# Altium schematic sheet I2C_Interface.SchDoc(I2C_Interface)
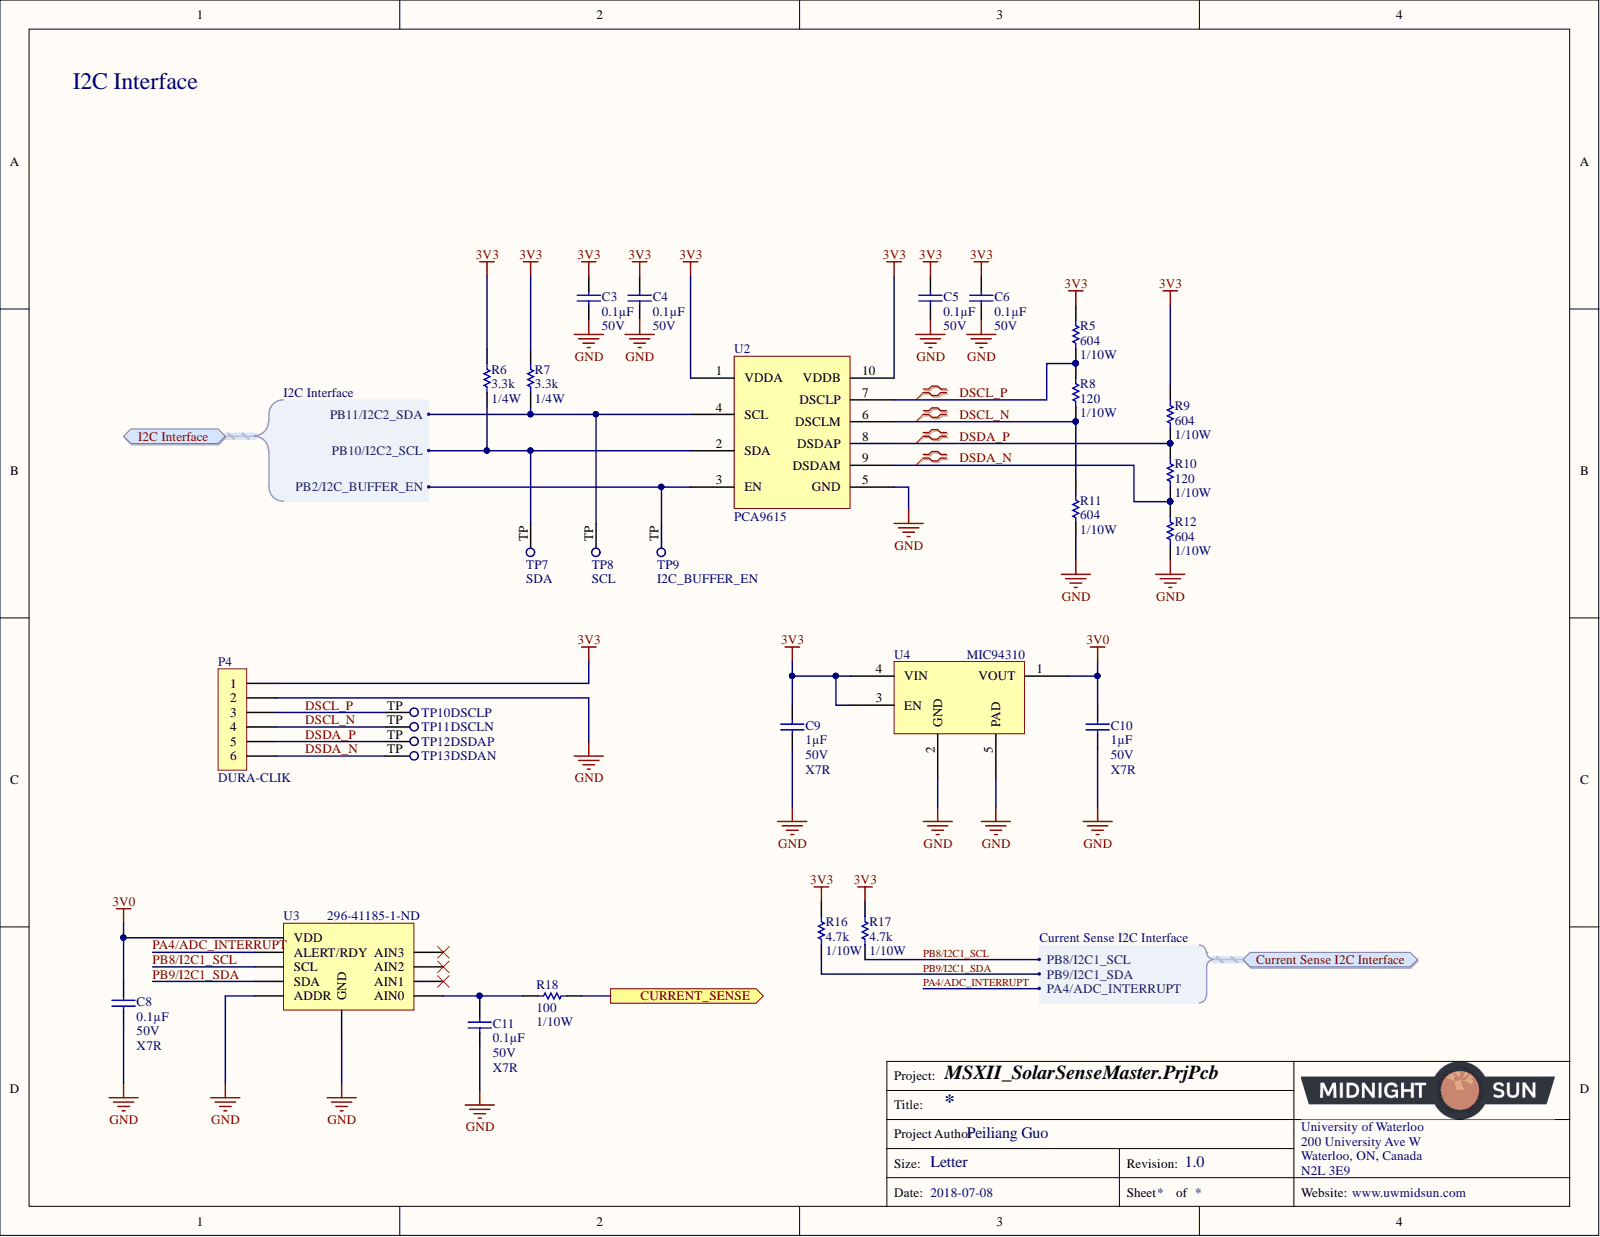
[diagram p0: I2C_Interface.SchDoc]

## Parts
C3  Voltage: 50V  Capacitance: 0.1µF  [CAP, 0603]  (hidden comment: CAP CER 0.1UF 50V 10% X7R 0603)
C4  Voltage: 50V  Capacitance: 0.1µF  [CAP, 0603]  (hidden comment: CAP CER 0.1UF 50V 10% X7R 0603)
C5  Voltage: 50V  Capacitance: 0.1µF  [CAP, 0603]  (hidden comment: CAP CER 0.1UF 50V 10% X7R 0603)
C6  Voltage: 50V  Capacitance: 0.1µF  [CAP, 0603]  (hidden comment: CAP CER 0.1UF 50V 10% X7R 0603)
C8  Voltage: 50V  Temperature Coefficient: X7R  Capacitance: 0.1µF  [CAP, 0603]  (hidden comment: CAP CER 0.1UF 50V 10% X7R 0603)
C9  Voltage: 50V  Temperature Coefficient: X7R  Capacitance: 1µF  [CAP, 0603]  (hidden comment: CAP CER 1UF 50V 10% X7R 0603)
C10  Voltage: 50V  Temperature Coefficient: X7R  Capacitance: 1µF  [CAP, 0603]  (hidden comment: CAP CER 1UF 50V 10% X7R 0603)
C11  Voltage: 50V  Temperature Coefficient: X7R  Capacitance: 0.1µF  [CAP, 0603]  (hidden comment: CAP CER 0.1UF 50V 10% X7R 0603)
P4  DURA-CLIK  [CONN, 6POS DURA-CLIK VERT]
R5  Power: 1/10W  [RES, 0603]  (hidden comment: RES 604 OHM 1% 1/10W 0603)
R6  Resistance: 3.3k  Power: 1/4W  [RES, 0603]  (hidden comment: RES 3.3K OHM 1% 1/4W 0603)
R7  Resistance: 3.3k  Power: 1/4W  [RES, 0603]  (hidden comment: RES 3.3K OHM 1% 1/4W 0603)
R8  Power: 1/10W  [RES, 0603]  (hidden comment: RES 120 OHM 1% 1/10W 0603)
R9  Power: 1/10W  [RES, 0603]  (hidden comment: RES 604 OHM 1% 1/10W 0603)
R10  Power: 1/10W  [RES, 0603]  (hidden comment: RES 120 OHM 1% 1/10W 0603)
R11  Power: 1/10W  [RES, 0603]  (hidden comment: RES 604 OHM 1% 1/10W 0603)
R12  Power: 1/10W  [RES, 0603]  (hidden comment: RES 604 OHM 1% 1/10W 0603)
R16  Resistance (Ohms): 4.7k  Power (Watts): 1/10W  [RES, 0603]  (hidden comment: RES 4.7K OHM 1% 1/10W 0603)
R17  Resistance (Ohms): 4.7k  Power (Watts): 1/10W  [RES, 0603]  (hidden comment: RES 4.7K OHM 1% 1/10W 0603)
R18  Power: 1/10W  [RES, 0603]  (hidden comment: RES 100 OHM 1% 1/10W 0603)
TP7  SDA  [Test Point]  (no BOM)
TP8  SCL  [Test Point]  (no BOM)
TP9  I2C_BUFFER_EN  [Test Point]  (no BOM)
TP10  DSCLP  [Test Point]  (no BOM)
TP11  DSCLN  [Test Point]  (no BOM)
TP12  DSDAP  [Test Point]  (no BOM)
TP13  DSDAN  [Test Point]  (no BOM)
U2  Base Part Number: PCA9615  [TSSOP50P490X110-10N]  (hidden comment: IC DIFFERENTIAL I2C BUFFER PCA9615)
U3  Supplier Part Number 1: 296-41185-1-ND  [IC ADC VSSOP10]  (hidden comment: Quad ADC)
U4  MIC94310  [IC REG LDO 3V 0.2A 4-TDFN]

## Ports
Current Sense I2C Interface, Current Sense I2C Interface.PA4/ADC_INTERRUPT, Current Sense I2C Interface.PB8/I2C1_SCL, Current Sense I2C Interface.PB9/I2C1_SDA, CURRENT_SENSE, I2C Interface, I2C Interface.PB2/I2C_BUFFER_EN, I2C Interface.PB10/I2C2_SCL, I2C Interface.PB11/I2C2_SDA

## Nets
3V0: C8.1 C10.1 U3.8(VDD) U4.1(VOUT)
3V3: C3.1 C4.1 C5.1 C6.1 C9.1 P4.1 R5.1 R6.1 R7.1 R9.1 R16.1 R17.1 U2.1(VDDA) U2.10(VDDB) U4.3(EN) U4.4(VIN)
GND: C3.2 C4.2 C5.2 C6.2 C8.2 C9.2 C10.2 C11.2 P4.2 R11.2 R12.2 U2.5(GND) U3.1(ADDR) U3.3(GND) U4.2(GND) U4.5(PAD)
CURRENT_SENSE: R18.1 ; port CURRENT_SENSE
DSCL_N: P4.4 R8.2 R11.1 TP11.TP U2.6(DSCLM)
DSCL_P: P4.3 R5.2 R8.1 TP10.TP U2.7(DSCLP)
DSDA_N: P4.6 R10.2 R12.1 TP13.TP U2.9(DSDAM)
DSDA_P: P4.5 R9.2 R10.1 TP12.TP U2.8(DSDAP)
PA4/ADC_INTERRUPT: U3.2(ALERT/RDY) ; port Current Sense I2C Interface
PB8/I2C1_SCL: R17.2 U3.10(SCL) ; port Current Sense I2C Interface
PB9/I2C1_SDA: R16.2 U3.9(SDA) ; port Current Sense I2C Interface
NetC11_1: C11.1 R18.2 U3.4(AIN0)
NetR6_2: R6.2 TP7.TP U2.2(SDA) ; port I2C Interface
NetR7_2: R7.2 TP8.TP U2.4(SCL) ; port I2C Interface
NetTP9_TP: TP9.TP U2.3(EN) ; port I2C Interface

Not connected: U3 5(AIN1) 6(AIN2) 7(AIN3)

## Library data of the parts
C3-C6 C8 C11 = CAP CER 0.1UF 50V 10% X7R 0603: 0.10µF ±10% 50V Ceramic Capacitor X7R 0603 (1608 Metric); Manufacturer Part Number 1: 06035C104KAT2A; Manufacturer 1: AVX Corporation; Tolerance: ±10%; Thickness (Max): 0.035" (0.90mm); Supplier Part Number 1: 478-5052-1-ND; Supplier 1: Digi-Key; Size / Dimension: 0.063" L x 0.032" W (1.60mm x 0.81mm); Ratings: -; Part Status: Active; Packaging: Cut Tape (CT); Package / Case: 0603 (1608 Metric); Operating Temperature: -55°C ~ 125°C; Mounting Type: Surface Mount, MLCC; Lead Style: -; Lead Spacing: -; Height - Seated (Max): -; ComponentLink1URL: http://datasheets.avx.com/X7RDielectric.pdf; ComponentLink1Description: http://datasheets.avx.com/X7RDielectric.pdf; Component Kind: Standard; Library Name: Schematic Diagrams.SchLib
  C3-C6: Temperature Coefficient: X7R
C9 C10 = CAP CER 1UF 50V 10% X7R 0603: 1µF ±10% 50V Ceramic Capacitor X7R 0603 (1608 Metric); Manufacturer Part Number 1: UMK107AB7105KA-T; Manufacturer 1: Taiyo Yuden; Tolerance: ±10%; Thickness (Max): 0.037" (0.95mm); Supplier Part Number 1: 587-3247-1-ND; Supplier 1: Digi-Key; Size / Dimension: 0.063" L x 0.031" W (1.60mm x 0.80mm); Ratings: -; Part Status: Active; Packaging: Cut Tape (CT); Package / Case: 0603 (1608 Metric); Operating Temperature: -55°C ~ 125°C; Mounting Type: Surface Mount, MLCC; Lead Style: -; Lead Spacing: -; Height - Seated (Max): -; ComponentLink1URL: http://www.yuden.co.jp/productdata/catalog/en/mlcc_all_e.pdf; ComponentLink1Description: http://www.yuden.co.jp/productdata/catalog/en/mlcc_all_e.pdf; Component Kind: Standard; Library Name: Schematic Diagrams.SchLib
P4 = CONN 6POS DURA-CLIK 0.079": 6 Positions Header, Shrouded Connector 0.079" (2.00mm) Surface Mount, Vertical Tin; Manufacturer Part Number: 5600200820; Manufacturer: Molex; Style: Board to Cable/Wire; Shrouding: Shrouded - 4 Wall; Number of Positions Loaded: All; Number of Positions: 6; Mated Stacking Heights: 9.3mm; Voltage Rating: -; Termination: Solder; Supplier Part Number 1: WM10866CT-ND; Supplier 1: Digi-Key; Stock 1: 3826; Row Spacing - Mating: -; RoHS: RoHS Compliant; Pricing 1: 1=1.76, 10=1.542, 25=1.4512, 50=1.391, 100=1.3305, 250=1.20956 (CAD); Pitch - Mating: 0.079" (2.00mm); Part Status: Active; Packaging: Cut Tape (CT); Overall Contact Length: -; Operating Temperature: -; Number of Rows: 1; Mounting Type: Surface Mount; Material Flammability Rating: -; Insulation Material: Polyamide (PA), Nylon; Insulation Height: 0.315" (8.00mm); Insulation Color: Natural; Ingress Protection: -; Features: Solder Retention; Fastening Type: Latch Lock; Current Rating: -; Contact Type: Male Pin; Contact Shape: Square; Contact Material: Copper Alloy; Contact Length - Post: -; Contact Length - Mating: -; Contact Finish Thickness - Post: -; Contact Finish Thickness - Mating: -; Contact Finish - Post: Tin; Contact Finish - Mating: Tin; Connector Type: Header; ComponentLink1URL: http://www.molex.com/pdm_docs/sd/5600200220_sd.pdf; ComponentLink1Description: http://www.molex.com/pdm_docs/sd/5600200220_sd.pdf; Component Kind: Standard; Category: Connectors, Interconnects; Applications: Automotive, Industrial; Library Name: Schematic Diagrams.SchLib
R5 R9 R11 R12 = RES 604 OHM 1% 1/10W 0603: RES SMD 604 OHM 1% 1/10W 0603; Manufacturer Part Number 1: RC0603FR-07604RL; Manufacturer 1: Yageo; Temperature Coefficient: ±100ppm/°C; Resistance: 604; Tolerance: ±1%; Supplier Part Number 1: 311-604HRCT-ND; Supplier Device Package: 0603; Supplier 1: Digi-Key; Size / Dimension: 0.063" L x 0.031" W (1.60mm x 0.80mm); Part Status: Active; Packaging: Cut Tape (CT); Package / Case: 0603 (1608 Metric); Operating Temperature: -55°C ~ 155°C; Height - Seated (Max): 0.022" (0.55mm); Failure Rate: -; Composition: Thick Film; ComponentLink1URL: http://www.yageo.com/documents/recent/PYu-RC_Group_51_RoHS_L_7.pdf; ComponentLink1Description: http://www.yageo.com/documents/recent/PYu-RC_Group_51_RoHS_L_7.pdf; Component Kind: Standard; Library Name: Schematic Diagrams.SchLib
R6 R7 = RES 3.3K OHM 1% 1/4W 0603: 3.3k Ohm ±1% 0.25W, 1/4W Chip Resistor 0603 (1608 Metric) Automotive AEC-Q200, Pulse Withstanding Thick Film; Manufacturer Part Number 1: ERJ-PA3F3301V; Manufacturer 1: Panasonic Electronic Components; Temperature Coefficient: ±100ppm/°C; Tolerance: ±1%; Supplier Part Number 1: P3.3KBYCT-ND; Supplier Device Package: 0603; Supplier 1: Digi-Key; Size / Dimension: 0.063" L x 0.031" W (1.60mm x 0.80mm); Part Status: Active; Packaging: Cut Tape (CT); Package / Case: 0603 (1608 Metric); Operating Temperature: -55°C ~ 155°C; Height - Seated (Max): 0.022" (0.55mm); Failure Rate: -; Composition: Thick Film; ComponentLink1URL: https://industrial.panasonic.com/cdbs/www-data/pdf/RDO0000/AOA0000C291.pdf; ComponentLink1Description: https://industrial.panasonic.com/cdbs/www-data/pdf/RDO0000/AOA0000C291.pdf; Component Kind: Standard; Library Name: Schematic Diagrams.SchLib
R8 R10 = RES 120 OHM 1% 1/10W 0603: RES SMD 120 OHM 1% 1/10W 0603; Manufacturer Part Number 1: RC0603FR-07120RL; Manufacturer 1: Yageo; Temperature Coefficient: ±100ppm/°C; Resistance: 120; Tolerance: ±1%; Supplier Part Number 1: 311-120HRCT-ND; Supplier Device Package: 0603; Supplier 1: Digi-Key; Size / Dimension: 0.063" L x 0.031" W (1.60mm x 0.80mm); Part Status: Active; Packaging: Cut Tape (CT); Package / Case: 0603 (1608 Metric); Operating Temperature: -55°C ~ 155°C; Height - Seated (Max): 0.022" (0.55mm); Failure Rate: -; Composition: Thick Film; ComponentLink1URL: http://www.yageo.com/documents/recent/PYu-RC_Group_51_RoHS_L_7.pdf; ComponentLink1Description: http://www.yageo.com/documents/recent/PYu-RC_Group_51_RoHS_L_7.pdf; Component Kind: Standard; Library Name: Schematic Diagrams.SchLib
R16 R17 = RES 4.7K OHM 1% 1/10W 0603: 4.7k Ohm ±1% 0.1W, 1/10W Chip Resistor 0603 (1608 Metric) Moisture Resistant Thick Film; Manufacturer Part Number 1: RC0603FR-074K7L; Manufacturer 1: Yageo; Temperature Coefficient: ±100ppm/°C; Description 1: RES SMD 4.7K OHM 1% 1/10W 0603; Tolerance: ±1%; Supplier Part Number 1: 311-4.70KHRCT-ND; Supplier Device Package: 0603; Supplier 1: Digi-Key; Stock 1: 3487566; Size / Dimension: 0.063" L x 0.031" W (1.60mm x 0.80mm); RoHS: RoHS Compliant; Pricing 1: 1=0.16, 10=0.022, 100=0.0089, 1000=0.00402, 2500=0.00349 (CAD); Part Status: Active; Packaging: Cut Tape (CT); Package / Case: 0603 (1608 Metric); Operating Temperature: -55°C ~ 155°C; Height - Seated (Max): 0.022" (0.55mm); Features: Moisture Resistant; Failure Rate: -; Composition: Thick Film; ComponentLink1URL: http://www.yageo.com/documents/recent/PYu-RC_Group_51_RoHS_L_7.pdf; ComponentLink1Description: http://www.yageo.com/documents/recent/PYu-RC_Group_51_RoHS_L_7.pdf; Component Kind: Standard; Category: Resistors; Library Name: Schematic Diagrams.SchLib
R18 = RES 100 OHM 1% 1/10W 0603: 100 Ohm ±1% 0.1W, 1/10W Chip Resistor 0603 (1608 Metric) Moisture Resistant Thick Film; Manufacturer Part Number 1: RC0603FR-07100RL; Manufacturer 1: Yageo; Temperature Coefficient: ±100ppm/°C; Resistance: 100; Tolerance: ±1%; Supplier Part Number 1: 311-100HRCT-ND; Supplier Device Package: 0603; Supplier 1: Digi-Key; Size / Dimension: 0.063" L x 0.031" W (1.60mm x 0.80mm); Part Status: Active; Packaging: Cut Tape (CT); Package / Case: 0603 (1608 Metric); Operating Temperature: -55°C ~ 155°C; Height - Seated (Max): 0.022" (0.55mm); Failure Rate: -; Composition: Thick Film; ComponentLink1URL: http://www.yageo.com/documents/recent/PYu-RC_Group_51_RoHS_L_7.pdf; ComponentLink1Description: http://www.yageo.com/documents/recent/PYu-RC_Group_51_RoHS_L_7.pdf; Component Kind: Standard; Library Name: Schematic Diagrams.SchLib
TP7-TP13 = Test Point: Library Name: Schematic Diagrams.SchLib
U2 = IC DIFFERENTIAL I2C BUFFER PCA9615: Buffer, ReDriver 2 Channel 400kHz 10-TSSOP; Manufacturer Part Number: PCA9615DPJ; Manufacturer: NXP USA Inc.; Voltage - Supply: 2.3 V ~ 5.5 V; Output: 2-Wire Bus; Number of Channels: 2; Current - Supply: 16µA; Type: Buffer, ReDriver; Supplier Part Number 1: 568-11484-1-ND; Supplier Device Package: 10-TSSOP; Supplier 1: Digi-Key; Stock 1: 7673; Signal Conditioning: -; RoHS: RoHS Compliant; Pricing 1: 1=4.38, 10=3.93, 100=3.2205, 500=2.74156, 1000=2.31216 (CAD); Part Status: Active; Packaging: Cut Tape (CT); Package / Case: 10-TFSOP, 10-MSOP (0.118", 3.00mm Width); Operating Temperature: -40°C ~ 85°C; Input: 2-Wire Bus; Delay Time: -; Data Rate (Max): 400kHz; ComponentLink1URL: http://www.nxp.com/documents/data_sheet/PCA9615.pdf; ComponentLink1Description: http://www.nxp.com/documents/data_sheet/PCA9615.pdf; Component Kind: Standard; Category: Integrated Circuits (ICs); Applications: I²C; Library Name: Schematic Diagrams.SchLib
U3 = IC ADC 12-BIT VSSOP-10: 12 Bit Analog to Digital Converter 4 Input 1 Sigma-Delta 10-VSSOP; Manufacturer Part Number: ADS1015IDGSR; Manufacturer: Texas Instruments; Voltage - Supply, Digital: 2 V ~ 5.5 V; Sampling Rate (Per Second): 3.3k; Reference Type: Internal; Number of Inputs: 2, 4; Number of Bits: 12; Number of A/D Converters: 1; Input Type: Differential, Single Ended; Data Interface: I²C; Configuration: MUX-PGA-ADC; Voltage - Supply, Analog: 2 V ~ 5.5 V; Supplier Device Package: 10-VSSOP; Supplier 1: Digi-Key; Stock 1: 4084; RoHS: RoHS Compliant; Ratio - S/H: -; Pricing 1: 1=4.25, 10=3.807, 100=3.1194, 500=2.65552, 1000=2.23959 (CAD); Part Status: Active; Packaging: Cut Tape (CT); Package / Case: 10-TFSOP, 10-MSOP (0.118", 3.00mm Width); Operating Temperature: -40°C ~ 125°C; Features: PGA, Selectable Address; ComponentLink1URL: http://www.ti.com/lit/ds/symlink/ads1013.pdf; ComponentLink1Description: http://www.ti.com/lit/ds/symlink/ads1013.pdf; Component Kind: Standard; Category: Integrated Circuits (ICs); Architecture: Sigma-Delta; Library Name: Schematic Diagrams.SchLib
U4 = IC REG LDO 3V 0.2A 4-TDFN: Manufacturer Part Number: MIC94310-PYMT-TR; Manufacturer: Microchip Technology; Voltage - Output: 3V; Voltage - Input: Up to 3.6V; Voltage - Dropout (Typical): 0.04V @ 200mA; Regulator Topology: Positive Fixed; Current - Output: 200mA; Current - Limit (Min): 250mA; Supplier Part Number 1: 576-4761-1-ND; Supplier Device Package: 4-TDFN (1.2x1.6); Supplier 1: Digi-Key; Stock 1: 4802; RoHS: RoHS Compliant; Pricing 1: 1=0.45, 25=0.3708, 100=0.3386 (CAD); Part Status: Active; Packaging: Cut Tape (CT); Package / Case: 4-UFDFN Exposed Pad; Operating Temperature: -40°C ~ 125°C; Mounting Type: Surface Mount; ComponentLink1URL: http://www.microchip.com/mymicrochip/filehandler.aspx?ddocname=en580409; ComponentLink1Description: http://www.microchip.com/mymicrochip/filehandler.aspx?ddocname=en580409; Component Kind: Standard; Category: Integrated Circuits (ICs); Library Name: Schematic Diagrams.SchLib
